# Altium schematic sheet Overview.SchDoc(Overview)
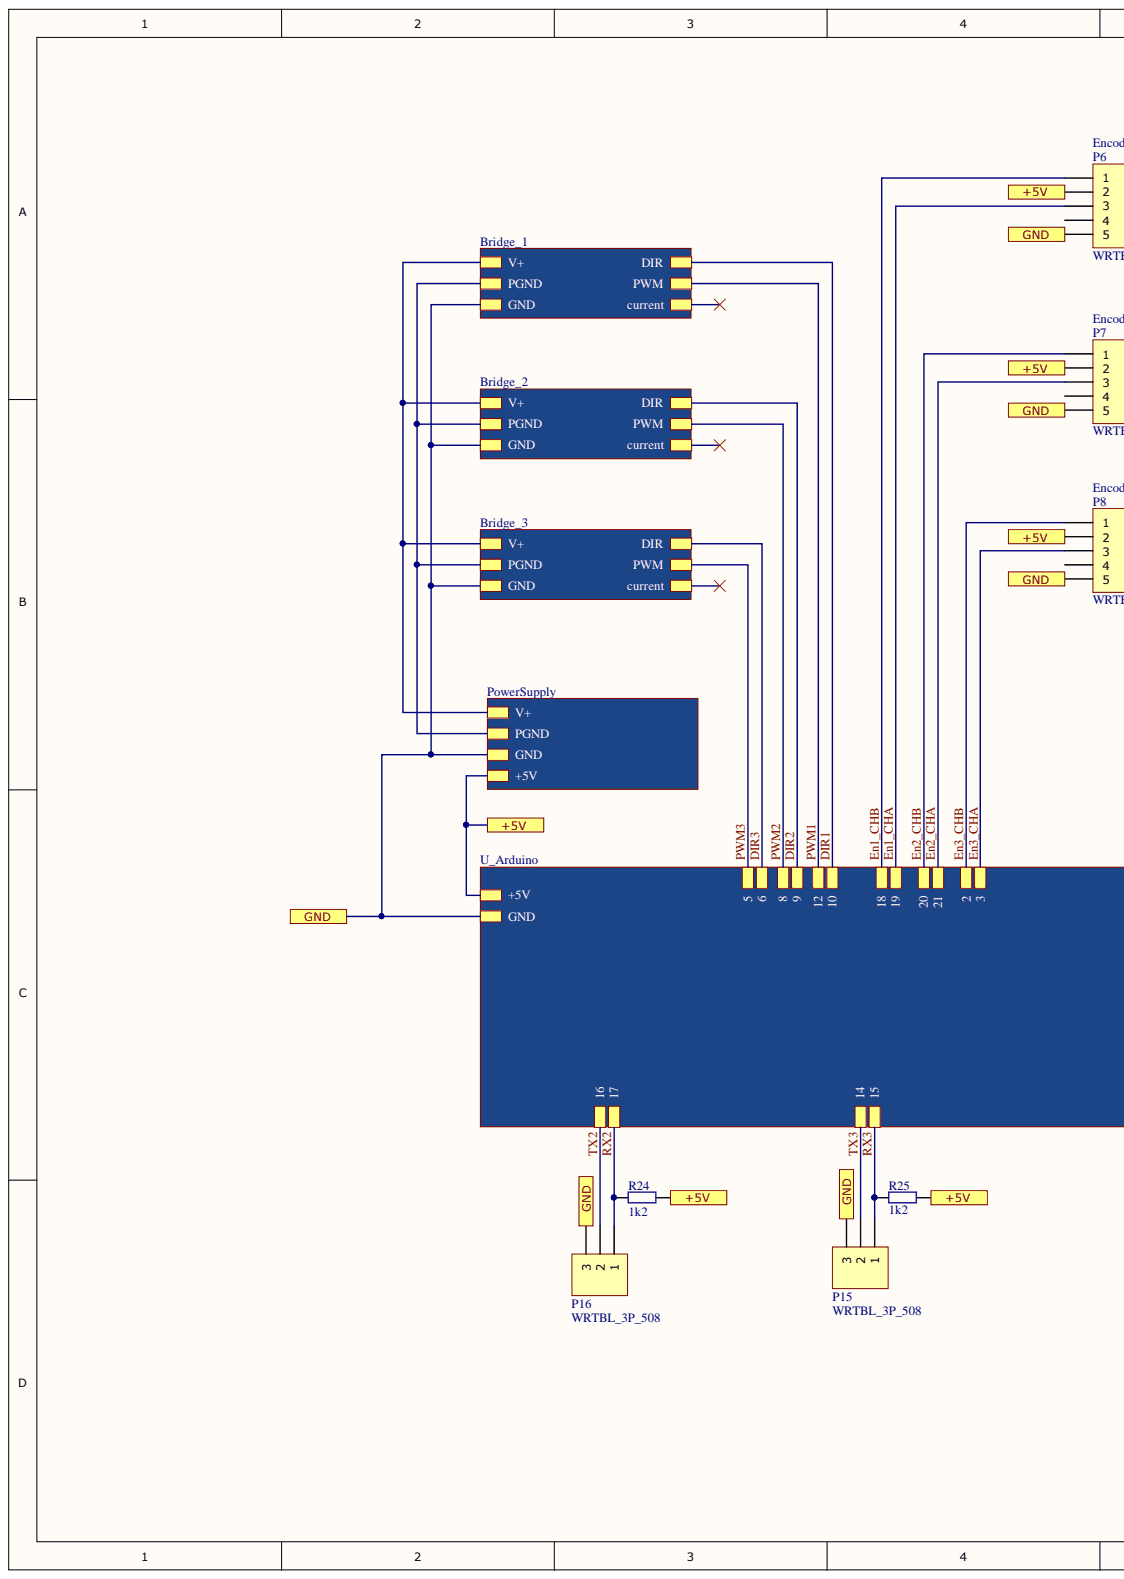
[diagram p0: Overview.SchDoc, left]
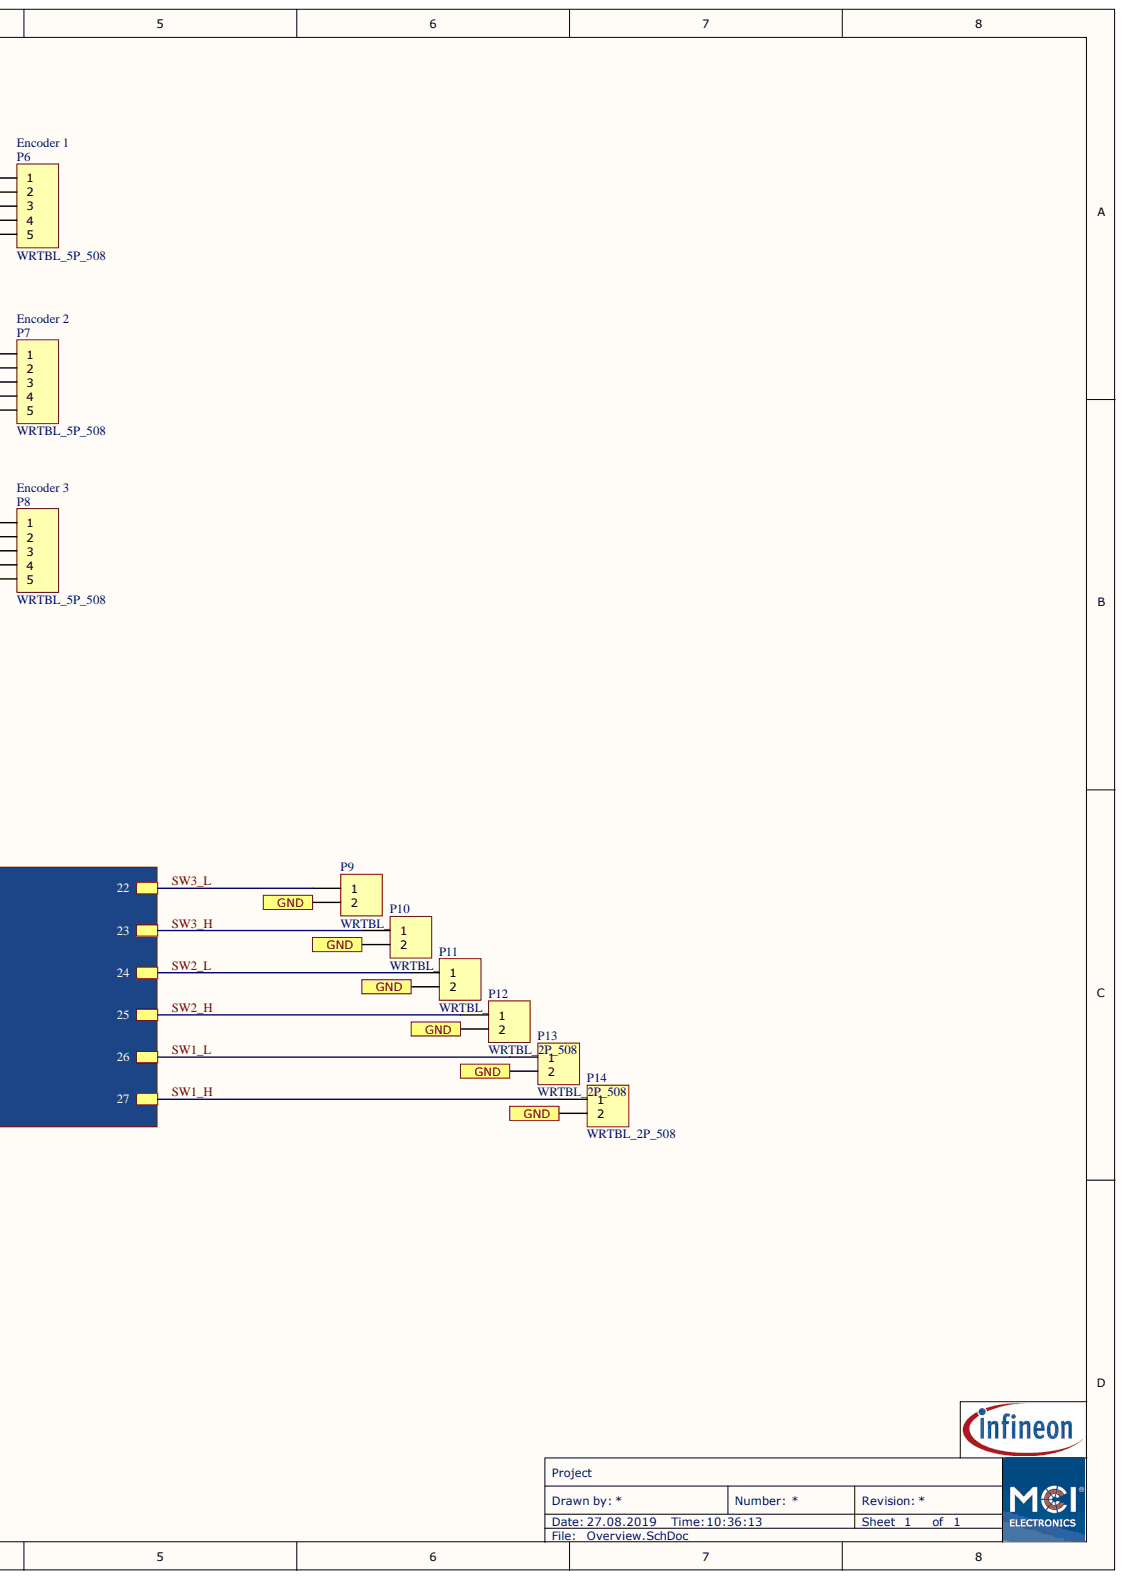
[diagram p1: Overview.SchDoc, right]

## Parts
P6  WRTBL_5P_508  [WRTBL_5P_508]
P7  WRTBL_5P_508  [WRTBL_5P_508]
P8  WRTBL_5P_508  [WRTBL_5P_508]
P9  WRTBL_2P_508  [WRTBL_2P_508]
P10  WRTBL_2P_508  [WRTBL_2P_508]
P11  WRTBL_2P_508  [WRTBL_2P_508]
P12  WRTBL_2P_508  [WRTBL_2P_508]
P13  WRTBL_2P_508  [WRTBL_2P_508]
P14  WRTBL_2P_508  [WRTBL_2P_508]
P15  WRTBL_3P_508  [WRTBL_3P_508]
P16  WRTBL_3P_508  [WRTBL_3P_508]
R24  1k2  [_0603]
R25  1k2  [_0603]

## Ports
+5V, GND

## Nets
+5V: P6.2 P7.2 P8.2 R24.2 R25.2 ; port +5V
En1_CHA: P6.3
En1_CHB: P6.1
En2_CHA: P7.3
En2_CHB: P7.1
En3_CHA: P8.3
En3_CHB: P8.1
GND: P6.5 P7.5 P8.5 P9.2 P10.2 P11.2 P12.2 P13.2 P14.2 P15.3 P16.3 ; port GND
RX2: P16.1 R24.1
RX3: P15.1 R25.1
SW1_H: P14.1
SW1_L: P13.1
SW2_H: P12.1
SW2_L: P11.1
SW3_H: P10.1
SW3_L: P9.1
TX2: P16.2
TX3: P15.2
NetP6_4: P6.4
NetP7_4: P7.4
NetP8_4: P8.4
current:
DIR1:
DIR2:
DIR3:
PGND:
PWM1:
PWM2:
PWM3:
V+:

## Library data of the parts
P6-P8 = Con_10: QR: 0; Database Table Name: Connectors$; UID: Con_10; Pins: 5; Name: WRTBL_5P_508; mounting: THT; Component Kind: Standard; Library Name: MCI_ALTIUM_LIB.DbLib
P9-P14 = Con_7: RS_number: 193-0586; QR: 0; Database Table Name: Connectors$; UID: Con_7; Pins: 2; Name_Hyperlink: Printklemme 5,08mm 2-polig; Name: WRTBL_2P_508; mounting: THT; ComponentLink1URL: http://at.rs-online.com/web/search/searchBrowseAction.html?method=getProduct&R=1930586; Component Kind: Standard; Library Name: MCI_ALTIUM_LIB.DbLib
P15 P16 = Con_8: QR: 0; Database Table Name: Connectors$; UID: Con_8; Pins: 3; Name: WRTBL_3P_508; mounting: THT; Component Kind: Standard; Library Name: MCI_ALTIUM_LIB.DbLib
R24 R25 = Res_5: Name: Res_0603; Database Table Name: Resistors$; UID: Res_5; mounting: SMD; ComponentLink1URL: #VALUE!; Component Kind: Standard; Library Name: MCI_ALTIUM_LIB.DbLib
